# Altium schematic sheet Comm.SchDoc(Comm)
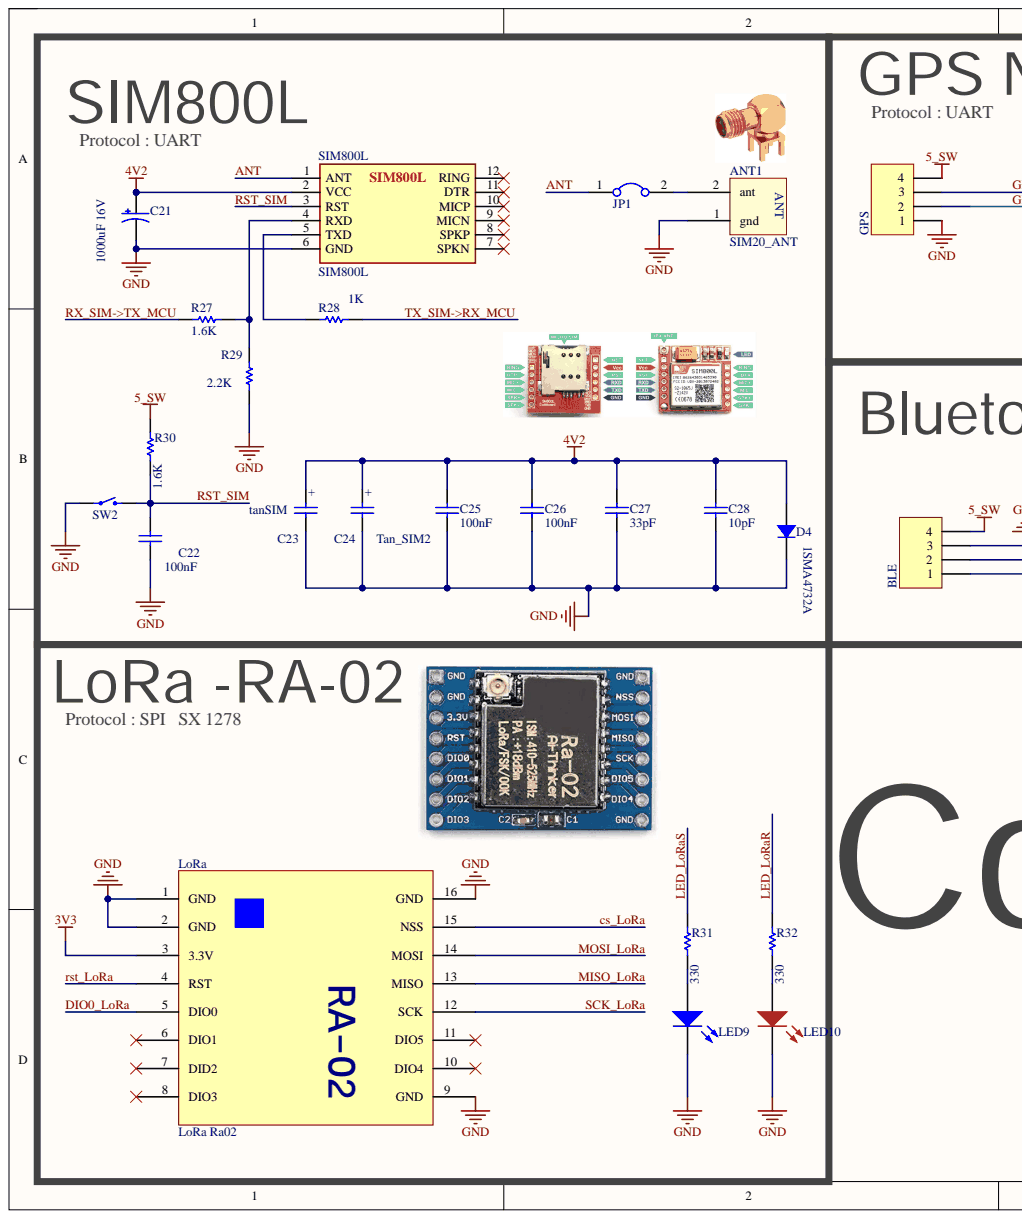
[diagram p0: Comm.SchDoc, left]
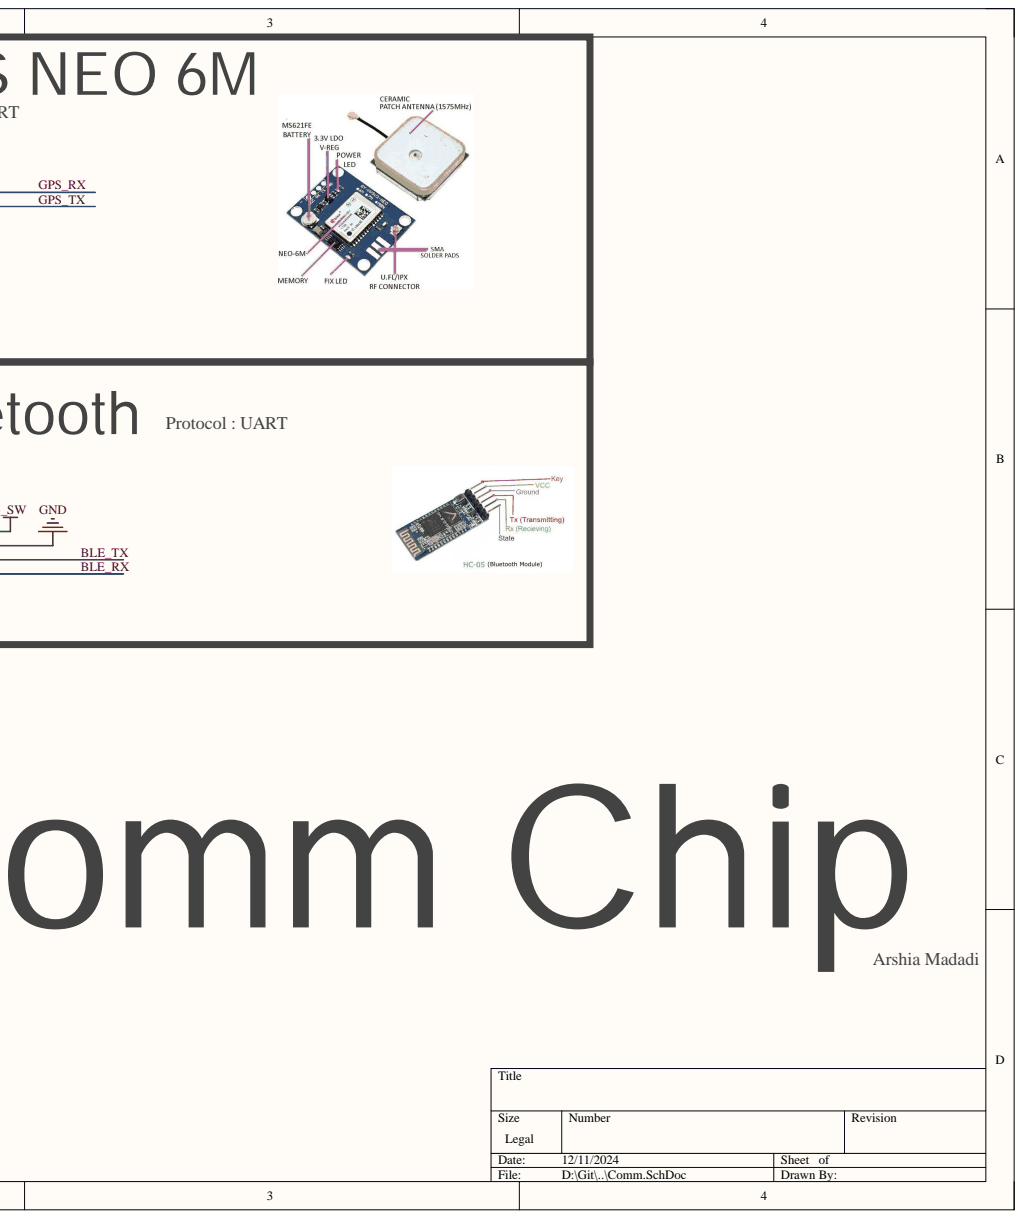
[diagram p1: Comm.SchDoc, right]

## Parts
ANT1  SIM20_ANT  [MY_SIM20_ANT]
BLE  Header 4  [My_1X04]  (value not drawn)
C21  1000uF 16V  [SMD_1000uf 16V]
C22  100nF  [MY_CAP_SMD_0603]
C23  tanSIM  [MY_CAP_SMD-C]
C24  Tan_SIM2  [MY_CAP_SMD-C]
C25  100nF  [1206 -cap]
C26  100nF  [1206 -cap]
C27  33pF  [1206 -cap]
C28  10pF  [1206 -cap]
D4  1SMA4732A  [DO-214AC SMA]
GPS  Header 4  [My_1X04]  (value not drawn)
JP1  Jumper  [Jumper Small - 2.54 - GRAY]  (value not drawn)
LED9  LED SMD 0805 BLUE  [LED_0805_RED]  (value not drawn)
LED10  LED SMD 0805 RED  [LED_0805_RED]  (value not drawn)
LoRa  LoRa Ra02  [LoRa - Ra-02]
R27  1.6K  [RESC3413X04N]
R28  1K  [RESC3413X04N]
R29  2.2K  [RESC3413X04N]
R30  1.6K  [RESC3413X04N]
R31  330  [RESC3413X04N]
R32  330  [RESC3413X04N]
SIM800L  SIM800L  [SIM800L]
SW2  Tactile 2PIN 6X3.5mm H4.57mm (SMD)  [SWPBS_SPST_6X3X5]  (value not drawn)

## Nets
3V3: LoRa.3(3.3V)
4V2: C21.1 C23.+ C24.+ C25.2 C26.2 C27.2 C28.2 D4.1 SIM800L.2(VCC)
5_SW: BLE.4 GPS.4 R30.2
GND: ANT1.1 BLE.3 C21.2 C22.2 C23.- C24.- C25.1 C26.1 C27.1 C28.1 D4.2 GPS.1 LED9.2 LED10.2 LoRa.1(GND) LoRa.2(GND) LoRa.9(GND) LoRa.16(GND) R29.2 SIM800L.6(GND) SW2.1
ANT: JP1.1 SIM800L.1(ANT)
BLE_RX: BLE.1
BLE_TX: BLE.2
cs_LoRa: LoRa.15(NSS)
DIO0_LoRa: LoRa.5(DIO0)
GPS_RX: GPS.3
GPS_TX: GPS.2
LED_LoRaR: R32.2
LED_LoRaS: R31.2
MISO_LoRa: LoRa.13(MISO)
MOSI_LoRa: LoRa.14(MOSI)
rst_LoRa: LoRa.4(RST)
RST_SIM: C22.1 R30.1 SIM800L.3(RST) SW2.2
RX_SIM->TX_MCU: R27.2
SCK_LoRa: LoRa.12(SCK)
TX_SIM->RX_MCU: R28.1
NetANT1_2: ANT1.2 JP1.2
NetLED9_1: LED9.1 R31.1
NetLED10_1: LED10.1 R32.1
NetR27_1: R27.1 R29.1 SIM800L.4(RXD)
NetR28_2: R28.2 SIM800L.5(TXD)

Not connected: LoRa 6(DIO1) 7(DID2) 8(DIO3) 10(DIO4) 11(DIO5); SIM800L 7(SPKN) 8(SPKP) 9(MICN) 10(MICP) 11(DTR) 12(RING)

## Library data of the parts
ANT1 = SIM20_ANT: ANT; Component Kind: Standard; Library Name: AMINLIB.IntLib
BLE GPS = Header 4: Header, 4-Pin; Component Kind: Standard; LatestRevisionDate: 17-Jul-2002; LatestRevisionNote: Re-released for DXP Platform.; Signal Integrity: Connector; Library Name: AMINLIB.IntLib; Publisher: Altium Limited
C21 = Capacitor: Electrolyte Capacitor; Component Kind: Standard; Library Name: Sajad_Altium_Library.IntLib
C22 = CAP SMD_0603: 1.6 mm × 0.8 mm; Component Kind: Standard; Library Name: AMINLIB.IntLib
C23 C24 = CAP_TANTAL_C: 6X3.2MM; Value: 3300u; Component Kind: Standard; Library Name: AMINLIB.IntLib
C25-C28 = Cap: Capacitor; Component Kind: Standard; LatestRevisionDate: 17-Jul-2002; LatestRevisionNote: Re-released for DXP Platform.; PackageReference: RAD-0.3; Published: 8-Jun-2000; Library Name: Sajad_Altium_Library.IntLib; Publisher: Altium Limited
D4 = US1M (SMD SMA): Diode Standard 1000V 1A Surface Mount SMA; PartNumber: US1M-13-F; Component Kind: Standard; Library Name: Diode.IntLib
JP1 = Jumper: Company: E.P.I.L; Defualt State: Close; Component Kind: Standard; Description: Jumper; Design Date: 2011/11/02; Library Name: Altium.IntLib
LoRa = LoRa: Component Kind: Standard; Library Name: LoRa-RA02.SchLib
R27-R32 = RES 0805 0.125W: RES 0805; Desc.: RES SMD 0805; Designer: Abbas Basereh; Component Kind: Standard; Data: 16-12-2016; Library Name: Altium.IntLib
SW2 = Tactile 2PIN 6X3.5mm H4.57mm (SMD): Tactile Switch SPST-NO Top Actuated Surface Mount; PartNumber: FSMSMTR-ND; Component Kind: Standard; Library Name: Switch.IntLib
LED9 = LED SMD 0805 BLUE: Component Kind: Standard; Library Name: Led.IntLib
LED10 = LED SMD 0805 RED: Component Kind: Standard; Library Name: Led.IntLib
SIM800L = SIM800L: Component Kind: Standard; Library Name: SIM800L.SchLib; Publisher: 3DFootprint.ir
